# Altium schematic sheet 02power.SchDoc(02power)
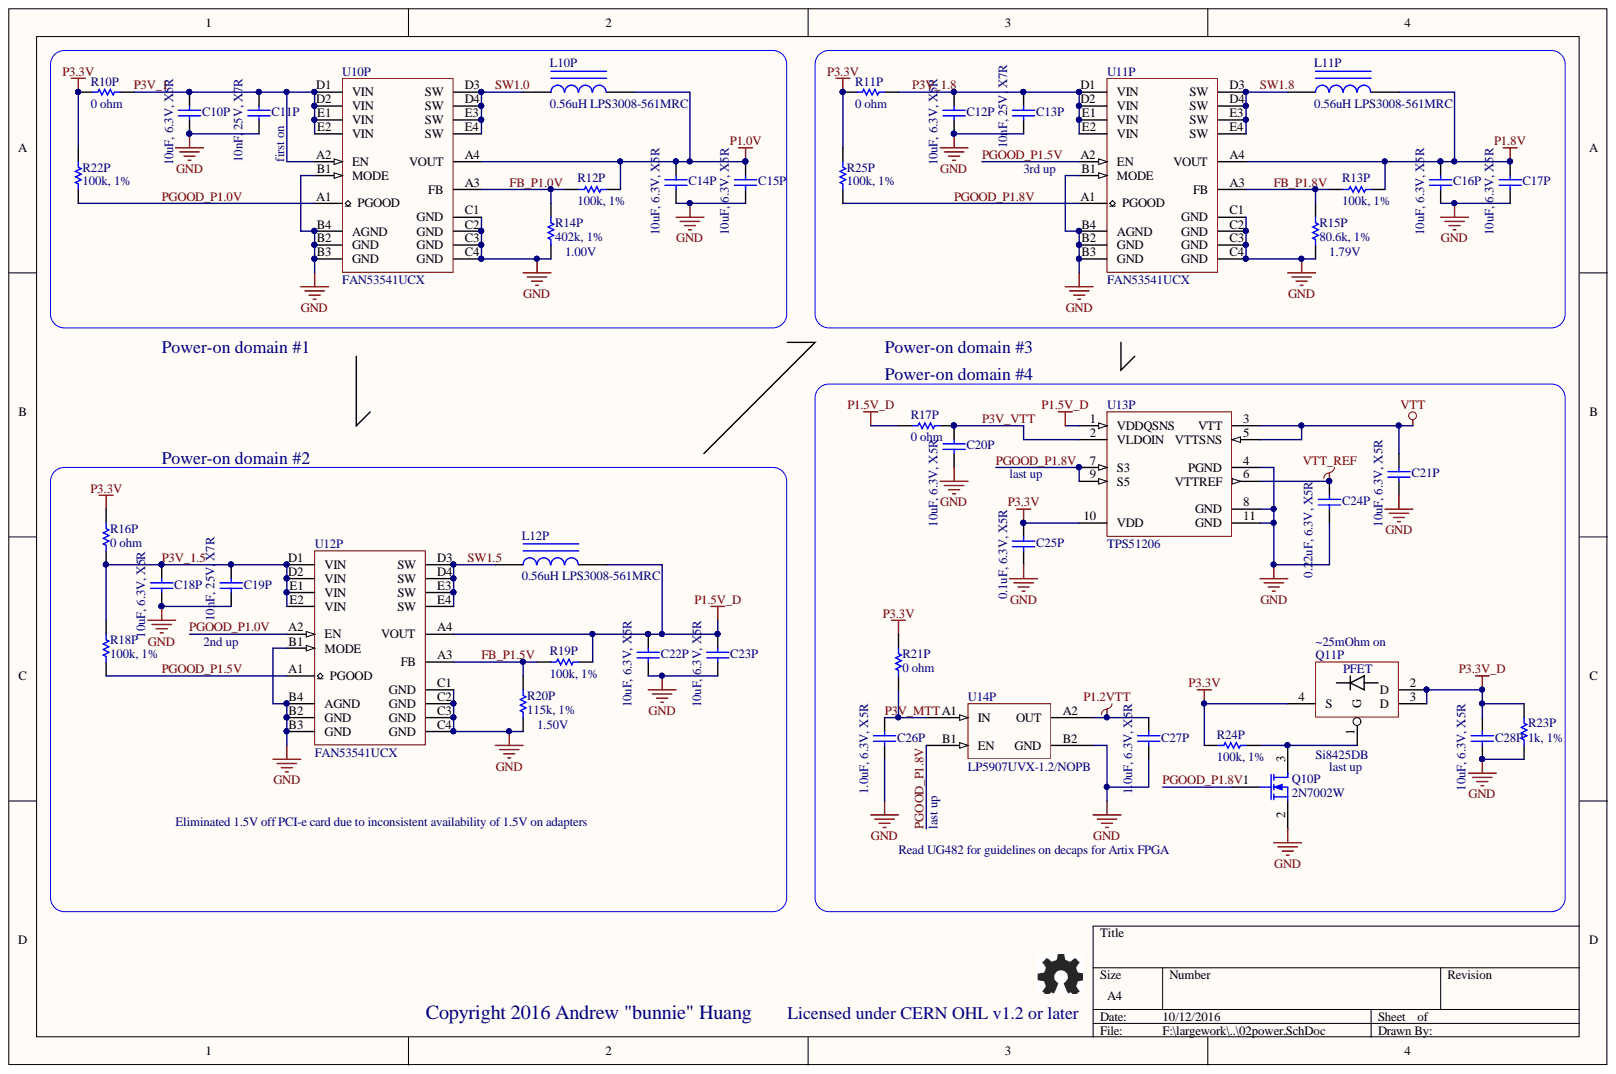
[diagram p0: 02power.SchDoc]

## Parts
C10P  10uF, 6.3V, X5R  [CAPC1608N]
C11P  10nF, 25V, X7R  [CAPC1005N]
C12P  10uF, 6.3V, X5R  [CAPC1608N]
C13P  10nF, 25V, X7R  [CAPC1005N]
C14P  10uF, 6.3V, X5R  [CAPC1608N]
C15P  10uF, 6.3V, X5R  [CAPC1608N]
C16P  10uF, 6.3V, X5R  [CAPC1608N]
C17P  10uF, 6.3V, X5R  [CAPC1608N]
C18P  10uF, 6.3V, X5R  [CAPC1608N]
C19P  10nF, 25V, X7R  [CAPC1005N]
C20P  10uF, 6.3V, X5R  [CAPC1608N]
C21P  10uF, 6.3V, X5R  [CAPC1608N]
C22P  10uF, 6.3V, X5R  [CAPC1608N]
C23P  10uF, 6.3V, X5R  [CAPC1608N]
C24P  0.22uF, 6.3V, X5R  [CAPC0603X03L]
C25P  0.1uF, 6.3V, X5R  [CAPC0603X03L]
C26P  1.0uF, 6.3V, X5R  [CAPC0603X03L]
C27P  1.0uF, 6.3V, X5R  [CAPC0603X03L]
C28P  10uF, 6.3V, X5R  [CAPC1608N]
L10P  0.56uH LPS3008-561MRC  MPN: LPS3008-561MRC  [COILCRAFT_LPS3008]
L11P  0.56uH LPS3008-561MRC  MPN: LPS3008-561MRC  [COILCRAFT_LPS3008]
L12P  0.56uH LPS3008-561MRC  MPN: LPS3008-561MRC  [COILCRAFT_LPS3008]
Q10P  2N7002W  [SOT323-3AN]
Q11P  Si8425DB  [VISHAY_MICRO_FOOT_4_BUMP]
R10P  0 ohm  [RESC1608N]
R11P  0 ohm  [RESC1608N]
R12P  100k, 1%  [RESC1005N]
R13P  100k, 1%  [RESC1005N]
R14P  402k, 1%  [RESC1005N]
R15P  80.6k, 1%  [RESC1005N]
R16P  0 ohm  [RESC1608N]
R17P  0 ohm  [RESC1608N]
R18P  100k, 1%  [RESC1005N]
R19P  100k, 1%  [RESC1005N]
R20P  115k, 1%  [RESC1005N]
R21P  0 ohm  [RESC1608N]
R22P  100k, 1%  [RESC1005N]
R23P  1k, 1%  [CAPC0603X03L]
R24P  100k, 1%  [RESC1005N]
R25P  100k, 1%  [RESC1005N]
U10P  FAN53541UCX  [BGA20C40P4X5_156X196X62]
U11P  FAN53541UCX  [BGA20C40P4X5_156X196X62]
U12P  FAN53541UCX  [BGA20C40P4X5_156X196X62]
U13P  TPS51206  [TI-DSQ-R-PWSON-N10]
U14P  LP5907UVX-1.2/NOPB  [TI_YKE004]

## Nets
GND: C10P.2 C11P.2 C12P.2 C13P.2 C14P.2 C15P.2 C16P.2 C17P.2 C18P.2 C19P.2 C20P.2 C21P.2 C22P.2 C23P.2 C24P.2 C25P.2 C26P.2 C27P.2 C28P.2 Q10P.2 R14P.2 R15P.2 R20P.2 R23P.2 U10P.B1(MODE) U10P.B2(GND) U10P.B3(GND) U10P.B4(AGND) U10P.C1(GND) U10P.C2(GND) U10P.C3(GND) U10P.C4(GND) U11P.B1(MODE) U11P.B2(GND) U11P.B3(GND) U11P.B4(AGND) U11P.C1(GND) U11P.C2(GND) U11P.C3(GND) U11P.C4(GND) U12P.B1(MODE) U12P.B2(GND) U12P.B3(GND) U12P.B4(AGND) U12P.C1(GND) U12P.C2(GND) U12P.C3(GND) U12P.C4(GND) U13P.4(PGND) U13P.8(GND) U13P.11(GND) U14P.B2(GND)
P1.0V: C14P.1 C15P.1 L10P.2 R12P.2 U10P.A4(VOUT)
P1.2VTT: C27P.1 U14P.A2(OUT)
P1.5V_D: C22P.1 C23P.1 L12P.2 R17P.2 R19P.2 U12P.A4(VOUT) U13P.1(VDDQSNS)
P1.8V: C16P.1 C17P.1 L11P.2 R13P.2 U11P.A4(VOUT)
P3.3V: C25P.1 Q11P.4(S) R10P.1 R11P.1 R16P.1 R21P.2 R22P.2 R24P.2 R25P.2 U13P.10(VDD)
P3.3V_D: C28P.1 Q11P.2(D) Q11P.3(D) R23P.1
VTT: C21P.1 U13P.3(VTT) U13P.5(VTTSNS)
VTT_REF: C24P.1 U13P.6(VTTREF)
FB_P1.0V: R12P.1 R14P.1 U10P.A3(FB)
FB_P1.5V: R19P.1 R20P.1 U12P.A3(FB)
FB_P1.8V: R13P.1 R15P.1 U11P.A3(FB)
P3V_1: C10P.1 C11P.1 R10P.2 U10P.A2(EN) U10P.D1(VIN) U10P.D2(VIN) U10P.E1(VIN) U10P.E2(VIN)
P3V_1.5: C18P.1 C19P.1 R16P.2 R18P.1 U12P.D1(VIN) U12P.D2(VIN) U12P.E1(VIN) U12P.E2(VIN)
P3V_1.8: C12P.1 C13P.1 R11P.2 U11P.D1(VIN) U11P.D2(VIN) U11P.E1(VIN) U11P.E2(VIN)
P3V_MTT: C26P.1 R21P.1 U14P.A1(IN)
P3V_VTT: C20P.1 R17P.1 U13P.2(VLDOIN)
PGOOD_P1.0V: R22P.1 U10P.A1(PGOOD) U12P.A2(EN)
PGOOD_P1.5V: R18P.2 U11P.A2(EN) U12P.A1(PGOOD)
PGOOD_P1.8V: Q10P.1 R25P.1 U11P.A1(PGOOD) U13P.7(S3) U13P.9(S5) U14P.B1(EN)
SW1.0: L10P.1 U10P.D3(SW) U10P.D4(SW) U10P.E3(SW) U10P.E4(SW)
SW1.5: L12P.1 U12P.D3(SW) U12P.D4(SW) U12P.E3(SW) U12P.E4(SW)
SW1.8: L11P.1 U11P.D3(SW) U11P.D4(SW) U11P.E3(SW) U11P.E4(SW)
NetQ10P_3: Q10P.3 Q11P.1(G) R24P.1

## Library data of the parts
C10P C12P C14P C15P C16P C17P C18P C20P C21P C22P C23P C28P = Cap Semi: Capacitor (Semiconductor SIM Model); MPN: CL10A106MQ8NNNC; MYPN: C-106-6.3-X5R-0603; VENDOR: Samsung; Component Kind: Standard; Library Name: Miscellaneous Devices.IntLib; Signal Integrity: Cap Semi; Simulation: CAP
C11P C13P C19P = Cap Semi: Capacitor (Semiconductor SIM Model); MPN: CL05B103KA5NNNC; MYPN: C-103-25-X7R-0402; VENDOR: Samsung; Component Kind: Standard; Library Name: Miscellaneous Devices.IntLib; Signal Integrity: Cap Semi; Simulation: CAP
C24P = Cap Semi: Capacitor (Semiconductor SIM Model); MPN: CL03A224MQ3NNNC; MYPN: C-224-6p3-X5R-0201; VENDOR: Samsung; Component Kind: Standard; Library Name: Miscellaneous Devices.IntLib; Signal Integrity: Cap Semi; Simulation: CAP
C25P = Cap Semi: Capacitor (Semiconductor SIM Model); MPN: CL03A104KQ3NNNC; MYPN: C-104-6p3-X5R-0201; VENDOR: Samsung; Component Kind: Standard; Library Name: Miscellaneous Devices.IntLib; Signal Integrity: Cap Semi; Simulation: CAP
C26P C27P = Cap Semi: Capacitor (Semiconductor SIM Model); MPN: CL03A105KQ3CSNC; MYPN: C-105-6p3-X5R-0201; VENDOR: Samsung; Component Kind: Standard; Library Name: Miscellaneous Devices.IntLib; Signal Integrity: Cap Semi; Simulation: CAP
L10P L11P L12P = Inductor Iron: Magnetic-Core Inductor; MYPN: L-LPS3008-561MR; VENDOR: COILCRAFT; Component Kind: Standard; Library Name: Miscellaneous Devices.IntLib; Signal Integrity: Inductor Iron; Simulation: INDUCTOR
Q10P = MOSFET-N: N-Channel MOSFET; MPN: 2N7002WT1G; MYPN: Q-2N7002W; VENDOR: ON Semiconductor; Component Kind: Standard; LatestRevisionDate: 17-Jul-2002; LatestRevisionNote: Re-released for DXP Platform.; Library Name: Miscellaneous Devices.IntLib; PackageReference: E3; PackageVersion: 1995/1996; Published: 8-Jun-2000; Simulation: NMOS; Publisher: Altium Limited
Q11P = Si8425DB: P-channel 20V 6.2A 27mOhm @ -2.5V; MPN: Si8425DB-T1-E1; MYPN: QSi8525; VENDOR: Vishay Siliconix; Component Kind: Standard; Library Name: temp-to-merge.SchLib
R10P R11P R16P R17P R21P = Res1: Resistor; MPN: ANY; MYPN: R-0R0-1P-1_16W-0603; Component Kind: Standard; Library Name: Miscellaneous Devices.IntLib; Signal Integrity: Res; Simulation: RESISTOR; VENDOR: ANY
R12P R13P R18P R19P R22P R24P R25P = Res1: Resistor; MPN: ANY; MYPN: R-104-1P-1_16W-0402; Component Kind: Standard; Library Name: Miscellaneous Devices.IntLib; Signal Integrity: Res; Simulation: RESISTOR; VENDOR: ANY
R14P = Res1: Resistor; MPN: ANY; MYPN: R-4023-1P-1_16W-0402; Component Kind: Standard; Library Name: Miscellaneous Devices.IntLib; Signal Integrity: Res; Simulation: RESISTOR; VENDOR: ANY
R15P = Res1: Resistor; MPN: ANY; MYPN: R-8062-1P-1_16W-0402; Component Kind: Standard; Library Name: Miscellaneous Devices.IntLib; Signal Integrity: Res; Simulation: RESISTOR; VENDOR: ANY
R20P = Res1: Resistor; MPN: ANY; MYPN: R-1153-1P-1_16W-0402; Component Kind: Standard; Library Name: Miscellaneous Devices.IntLib; Signal Integrity: Res; Simulation: RESISTOR; VENDOR: ANY
R23P = Res1: Resistor; MPN: ANY; MYPN: R-102-1P-1_16W-0201; Component Kind: Standard; Library Name: Miscellaneous Devices.IntLib; Signal Integrity: Res; Simulation: RESISTOR; VENDOR: ANY
U10P U11P U12P = FAN53541UCX: 2.4MHz, 5A TinyBuck Synchronous Buck Regulator; MYPN: UFAN53541UCX; VENDOR: Fairchild Semiconductor; Component Kind: Standard; Library Name: commonsch-new.SchLib; MPN: FAN53541UCX
U13P = TPS51206: 2A-peak sink/source DDR termination regulator; MPN: TPS51206DSQ(T/R); MYPN: UTPS51206DSQ; VENDOR: TI; Component Kind: Standard; Library Name: temp-to-merge.SchLib
U14P = LP5907UVX: Ultra low-noise 250mA LDO for RF and analog in DSBGA; MYPN: ULP5907UVX-1.2; VENDOR: TI; Component Kind: Standard; Library Name: commonsch-new.SchLib; MPN: LP5907UVX-1.2/NOPB
